# Altium schematic sheet Sheet2.SchDoc
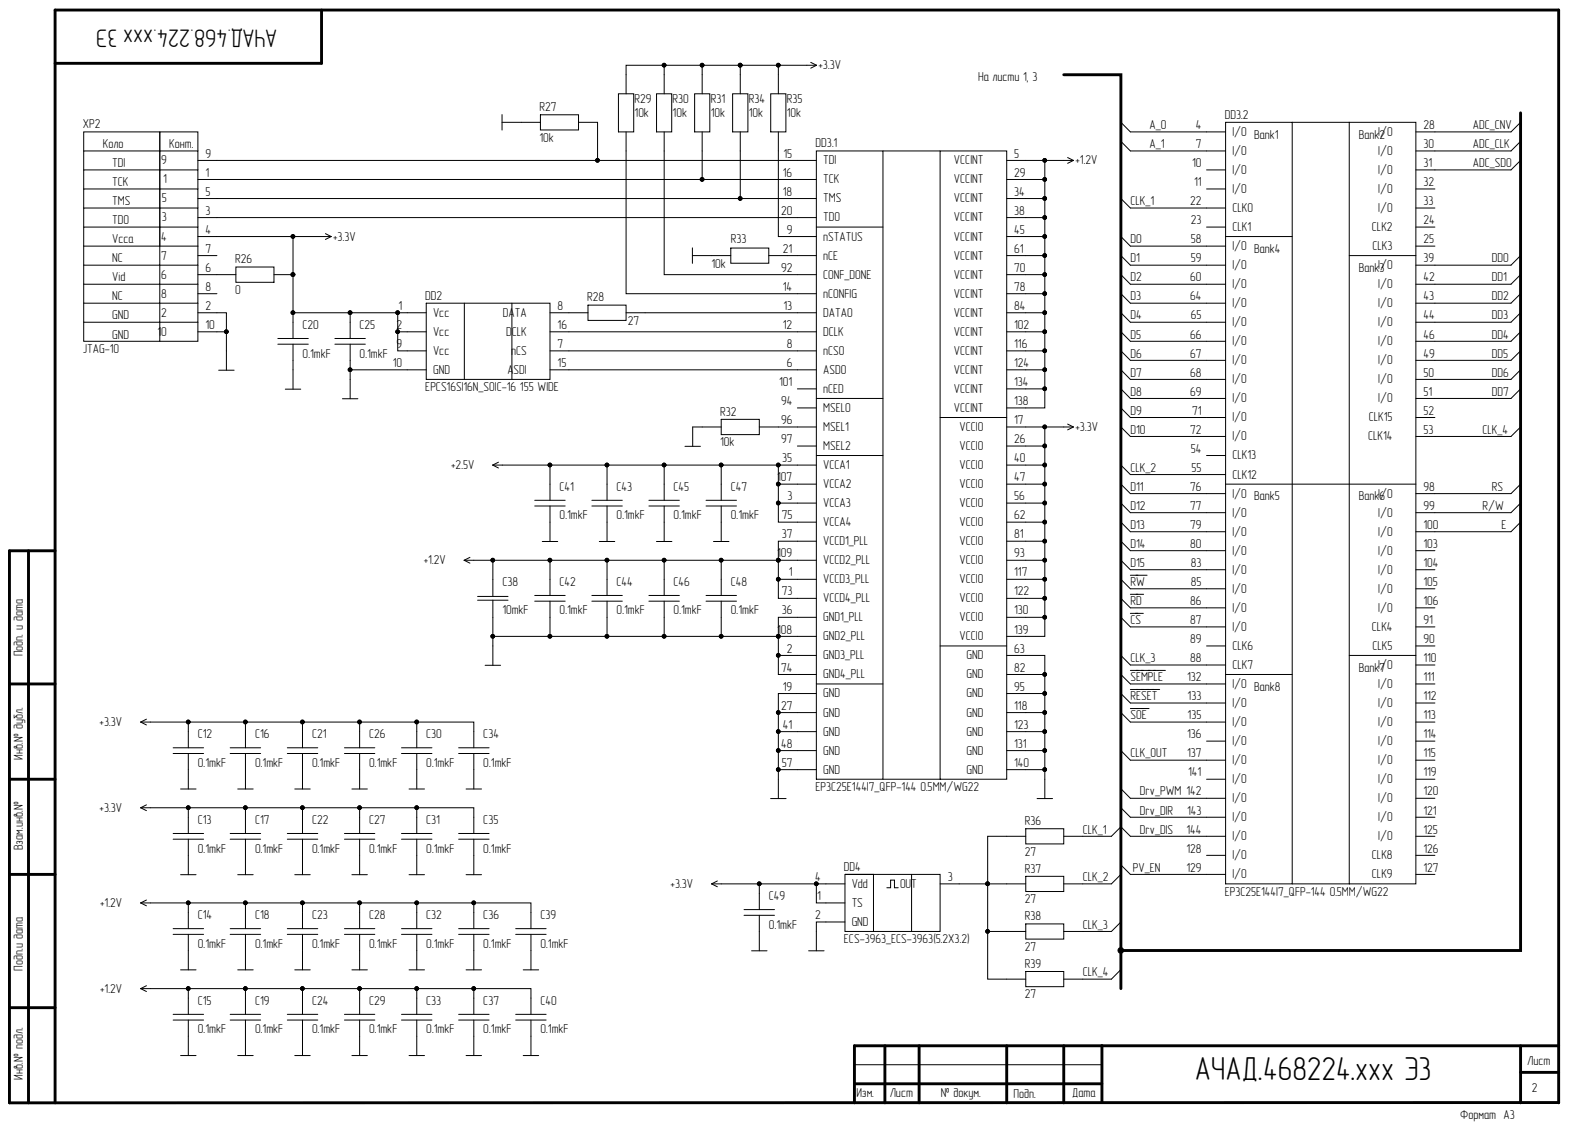
[diagram p0: Sheet2.SchDoc]

## Parts
C12  0.1mkF  [C_0603]
C13  0.1mkF  [C_0603]
C14  0.1mkF  [C_0603]
C15  0.1mkF  [C_0603]
C16  0.1mkF  [C_0603]
C17  0.1mkF  [C_0603]
C18  0.1mkF  [C_0603]
C19  0.1mkF  [C_0603]
C20  0.1mkF  [C_0603]
C21  0.1mkF  [C_0603]
C22  0.1mkF  [C_0603]
C23  0.1mkF  [C_0603]
C24  0.1mkF  [C_0603]
C25  0.1mkF  [C_0603]
C26  0.1mkF  [C_0603]
C27  0.1mkF  [C_0603]
C28  0.1mkF  [C_0603]
C29  0.1mkF  [C_0603]
C30  0.1mkF  [C_0603]
C31  0.1mkF  [C_0603]
C32  0.1mkF  [C_0603]
C33  0.1mkF  [C_0603]
C34  0.1mkF  [C_0603]
C35  0.1mkF  [C_0603]
C36  0.1mkF  [C_0603]
C37  0.1mkF  [C_0603]
C38  10mkF  [C_1206]
C39  0.1mkF  [C_0603]
C40  0.1mkF  [C_0603]
C41  0.1mkF  [C_0603]
C42  0.1mkF  [C_0603]
C43  0.1mkF  [C_0603]
C44  0.1mkF  [C_0603]
C45  0.1mkF  [C_0603]
C46  0.1mkF  [C_0603]
C47  0.1mkF  [C_0603]
C48  0.1mkF  [C_0603]
C49  0.1mkF  [C_0603]
DD2  EPCS16SI16N_SOIC-16 155 WIDE  [SOIC127P600X175-16N]
DD3  EP3C25E144I7_QFP-144 0.5MM/WG22  [QFP65P3122X3122X395-144N]  (parts .1,.2)
DD4  ECS-3963_ECS-3963(5.2X3.2)  [ECS-3961]
R26  0  [R_1206]
R27  10k  [R_0603]
R28  27  [R_1206]
R29  10k  [R_0603]
R30  10k  [R_0603]
R31  10k  [R_0603]
R32  10k  [R_0603]
R33  10k  [R_0603]
R34  10k  [R_0603]
R35  10k  [R_0603]
R36  27  [R_0603]
R37  27  [R_0603]
R38  27  [R_0603]
R39  27  [R_0603]
XP2  JTAG-10

## Nets
+1.2V: C14.2 C15.2 C18.2 C19.2 C23.2 C24.2 C28.2 C29.2 C32.2 C33.2 C36.2 C37.2 C38.2 C39.2 C40.2 C42.2 C44.2 C46.2 C48.2 DD3.1(VCCD3_PLL) DD3.5 DD3.29 DD3.34 DD3.37(VCCD1_PLL) DD3.38 DD3.45 DD3.61 DD3.70 DD3.73(VCCD4_PLL) DD3.78 DD3.84 DD3.102 DD3.109(VCCD2_PLL) DD3.116 DD3.124 DD3.134 DD3.138
+2.5V: C41.2 C43.2 C45.2 C47.2 DD3.3(VCCA3) DD3.35(VCCA1) DD3.75(VCCA4) DD3.107(VCCA2)
+3.3V: C12.2 C13.2 C16.2 C17.2 C20.2 C21.2 C22.2 C25.2 C26.2 C27.2 C30.2 C31.2 C34.2 C35.2 C49.2 DD2.1(Vcc) DD2.2(Vcc) DD2.9(Vcc) DD3.17 DD3.26 DD3.40 DD3.47 DD3.56 DD3.62 DD3.81 DD3.93 DD3.117 DD3.122 DD3.130 DD3.139 DD4.1(TS) DD4.4(Vdd) R26.1 R29.2 R30.2 R31.2 R34.2 R35.2 XP2.4
GND: C12.1 C13.1 C14.1 C15.1 C16.1 C17.1 C18.1 C19.1 C20.1 C21.1 C22.1 C23.1 C24.1 C25.1 C26.1 C27.1 C28.1 C29.1 C30.1 C31.1 C32.1 C33.1 C34.1 C35.1 C36.1 C37.1 C38.1 C39.1 C40.1 C41.1 C42.1 C43.1 C44.1 C45.1 C46.1 C47.1 C48.1 C49.1 DD2.10(GND) DD3.2(GND3_PLL) DD3.19(GND) DD3.27(GND) DD3.36(GND1_PLL) DD3.41(GND) DD3.48(GND) DD3.57(GND) DD3.63 DD3.74(GND4_PLL) DD3.82 DD3.95 DD3.108(GND2_PLL) DD3.118 DD3.123 DD3.131 DD3.140 DD4.2(GND) R27.1 R32.1 R33.1 XP2.2 XP2.10
A_0: DD3.4(I/O)
A_1: DD3.7(I/O)
ADC_CLK: DD3.30
ADC_CNV: DD3.28
ADC_SDO: DD3.31
~{CS}: DD3.87(I/O)
CLK_1: DD3.22(CLK0) R36.2
CLK_2: DD3.55(CLK12) R37.2
CLK_3: DD3.88(CLK7) R38.2
CLK_4: DD3.53 R39.2
CLK_OUT: DD3.137(I/O)
D0: DD3.58(I/O)
D1: DD3.59(I/O)
D2: DD3.60(I/O)
D3: DD3.64(I/O)
D4: DD3.65(I/O)
D5: DD3.66(I/O)
D6: DD3.67(I/O)
D7: DD3.68(I/O)
D8: DD3.69(I/O)
D9: DD3.71(I/O)
D10: DD3.72(I/O)
D11: DD3.76(I/O)
D12: DD3.77(I/O)
D13: DD3.79(I/O)
D14: DD3.80(I/O)
D15: DD3.83(I/O)
DD0: DD3.39
DD1: DD3.42
DD2: DD3.43
DD3: DD3.44
DD4: DD3.46
DD5: DD3.49
DD6: DD3.50
DD7: DD3.51
DRV_DIR: DD3.143(I/O)
DRV_DIS: DD3.144(I/O)
DRV_PWM: DD3.142(I/O)
E: DD3.100
PV_EN: DD3.129(I/O)
R/W: DD3.99
~{RD}: DD3.86(I/O)
~{RESET}: DD3.133(I/O)
~{RW}: DD3.85(I/O)
RS: DD3.98
~{SEMPLE}: DD3.132(I/O)
~{SOE}: DD3.135(I/O)
NetDD2_7: DD2.7 DD3.8(nCSO)
NetDD2_8: DD2.8 R28.1
NetDD2_15: DD2.15 DD3.6(ASDO)
NetDD2_16: DD2.16 DD3.12(DCLK)
NetDD3_9: DD3.9(nSTATUS) R35.1
NetDD3_13: DD3.13(DATA0) R28.2
NetDD3_14: DD3.14(nCONFIG) R29.1
NetDD3_15: DD3.15(TDI) R27.2 XP2.9
NetDD3_16: DD3.16(TCK) R31.1 XP2.1
NetDD3_18: DD3.18(TMS) R34.1 XP2.5
NetDD3_20: DD3.20(TD0) XP2.3
NetDD3_21: DD3.21(nCE) R33.2
NetDD3_92: DD3.92(CONF_DONE) R30.1
NetDD3_96: DD3.96(MSEL1) R32.2
NetDD4_3: DD4.3 R36.1 R37.1 R38.1 R39.1
NetR26_2: R26.2 XP2.6
N00124:

Not connected: DD3 10(I/O) 11(I/O) 23(CLK1) 24 25 32 33 52 54(CLK13) 89(CLK6) 90 91 94(MSEL0) 97(MSEL2) 101(nCED) 103 104 105 106 110 111 112 113 114 115 119 120 121 125 126 127 128(I/O) 136(I/O) 141(I/O); XP2 7 8

## Library data of the parts
C12-C37 C39-C49 = C_0.1mkF_0603: Component Kind: Standard; Description: C_0.1mkF_0603; Value: 0.1mkF; Library Name: АСК_1.SchLib
C38 = C_10mkF_1206: Component Kind: Standard; Description: C_10mkF_1206; Value: 10mkF; Library Name: АСК_1.SchLib
R26 R28 = R_10_1206: Component Kind: Standard; Description: R_10_1206; Library Name: АСК_1.SchLib
  R26: Value: 0
  R28: Value: 27
R27 R29-R39 = R_10k_0603: Component Kind: Standard; Description: R_10k_0603; Library Name: АСК_1.SchLib
  R27 R29-R35: Value: 10k
  R36-R39: Value: 27
DD2 = EPCS16SI16N_SOIC-16 155 WIDE: Component Kind: Standard; Description: EPCS16SI16N_SOIC-16 155 WIDE; Library Name: АСК_1.SchLib
DD3 = EP3C25E144I7_QFP-144 0.5MM/WG22: Component Kind: Standard; Description: EP3C25E144I7_QFP-144 0.5MM/WG22; Library Name: АСК_1.SchLib
DD4 = ECS-3963_ECS-3963(5.2X3.2): Component Kind: Standard; Description: ECS-3963_ECS-3963(5.2X3.2); Library Name: АСК_1.SchLib
XP2 = JTAG-10: Component Kind: Standard; Library Name: АСК_1.SchLib
